# Altium schematic sheet IO.SchDoc(IO)
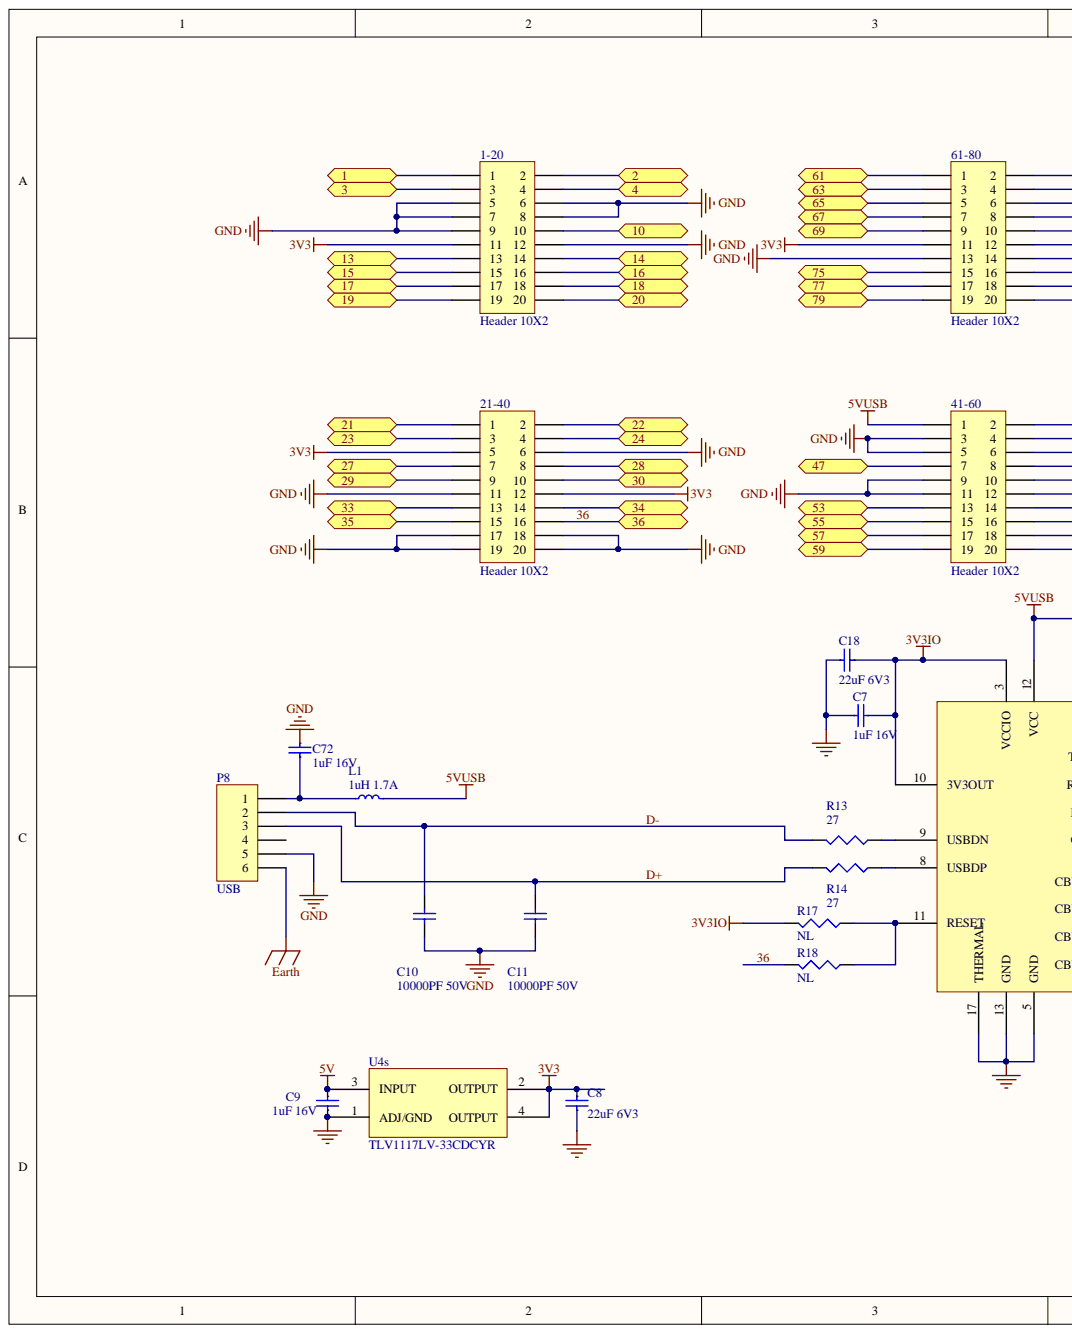
[diagram p0: IO.SchDoc, left]
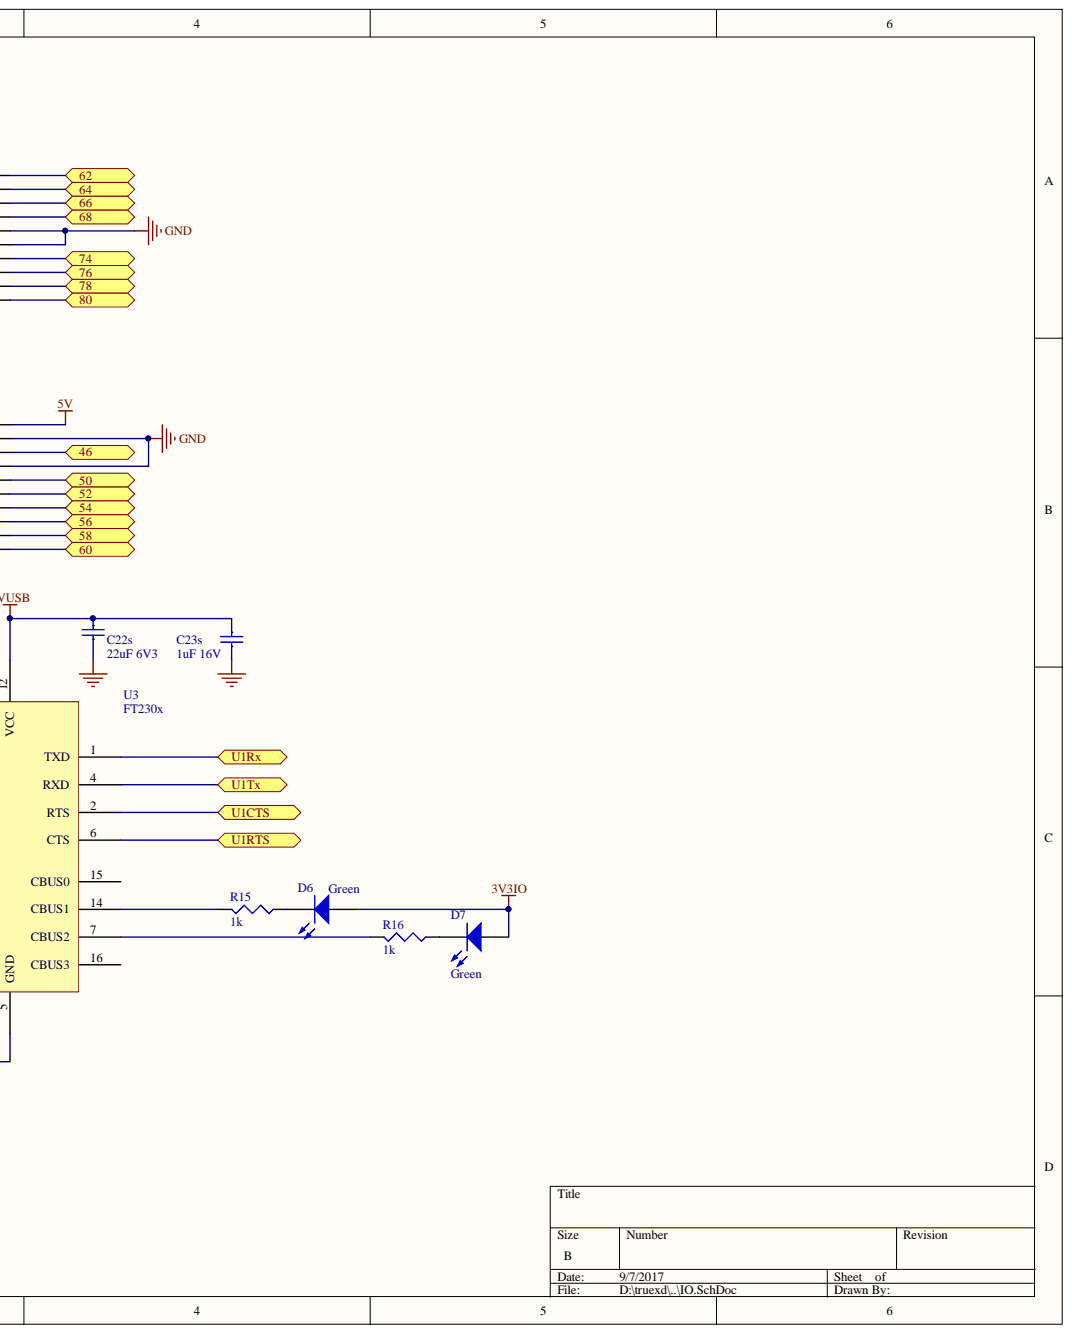
[diagram p1: IO.SchDoc, right]

## Parts
1-20  Header 10X2  [HDR2X10]
21-40  Header 10X2  [HDR2X10]
41-60  Header 10X2  [HDR2X10]
61-80  Header 10X2  [HDR2X10]
C7  1uF 16V  [CAP-0306 - BARE]
C8  22uF 6V3  [2012[0805]]
C9  1uF 16V  [CAP-0306 - BARE]
C10  10000PF 50V  [RES-0603 - Small]
C11  10000PF 50V  [RES-0603 - Small]
C18  22uF 6V3  [2012[0805]]
C22s  22uF 6V3  [2012[0805]]
C23s  1uF 16V  [CAP-0306 - BARE]
C72  1uF 16V  [CAP-0306 - BARE]
D6  Green  [LED_0603]
D7  Green  [LED_0603]
L1  1uH 1.7A  [IND-SMT-2]
P8  USB  [usb_mini_B_header]
R13  27  [RES-0603 - Small]
R14  27  [RES-0603 - Small]
R15  1k  [RES-0603 - Small]
R16  1k  [RES-0603 - Small]
R17  NL  [RES-0603 - Small]
R18  NL  [RES-0603 - Small]
U3  FT230x  [QFN16]
U4s  TLV1117LV-33CDCYR  [SOT-223]

## Ports
1, 2, 3, 4, 10, 13, 14, 15, 16, 17, 18, 19, 20, 21, 22, 23, 24, 27, 28, 29, 30, 33, 34, 35, 36, 46, 47, 50, 52, 53, 54, 55, 56, 57, 58, 59, 60, 61, 62, 63, 64, 65, 66, 67, 68, 69, 74, 75, 76, 77, 78, 79, 80, U1CTS, U1RTS, U1Rx, U1Tx

## Nets
3V3: 1-20.11 21-40.5 21-40.12 61-80.11 C8.2 U4s.2(OUTPUT) U4s.4(OUTPUT)
3V3IO: C7.1 C18.1 D6.1 D7.1 R17.2 U3.3(VCCIO) U3.10(3V3OUT)
5V: 41-60.2 C9.2 U4s.3(INPUT)
5VUSB: 41-60.1 C22s.2 C23s.2 L1.2 U3.12(VCC)
Earth: P8.6
GND: 1-20.5 1-20.6 1-20.7 1-20.8 1-20.9 1-20.12 21-40.6 21-40.11 21-40.17 21-40.18 21-40.19 21-40.20 41-60.3 41-60.4 41-60.5 41-60.8 41-60.9 41-60.11 61-80.10 61-80.12 61-80.13 C7.2 C8.1 C9.1 C10.1 C11.1 C18.2 C22s.1 C23s.1 C72.1 P8.5 U3.5(GND) U3.13(GND) U3.17(THERMAL) U4s.1(ADJ/GND)
1: 1-20.1 ; port 1
2: 1-20.2 ; port 2
3: 1-20.3 ; port 3
4: 1-20.4 ; port 4
10: 1-20.10 ; port 10
13: 1-20.13 ; port 13
14: 1-20.14 ; port 14
15: 1-20.15 ; port 15
16: 1-20.16 ; port 16
17: 1-20.17 ; port 17
18: 1-20.18 ; port 18
19: 1-20.19 ; port 19
20: 1-20.20 ; port 20
21: 21-40.1 ; port 21
22: 21-40.2 ; port 22
23: 21-40.3 ; port 23
24: 21-40.4 ; port 24
27: 21-40.7 ; port 27
28: 21-40.8 ; port 28
29: 21-40.9 ; port 29
30: 21-40.10 ; port 30
33: 21-40.13 ; port 33
34: 21-40.14 ; port 34
35: 21-40.15 ; port 35
36: 21-40.16 R18.2 ; port 36
46: 41-60.6 ; port 46
47: 41-60.7 ; port 47
50: 41-60.10 ; port 50
52: 41-60.12 ; port 52
53: 41-60.13 ; port 53
54: 41-60.14 ; port 54
55: 41-60.15 ; port 55
56: 41-60.16 ; port 56
57: 41-60.17 ; port 57
58: 41-60.18 ; port 58
59: 41-60.19 ; port 59
60: 41-60.20 ; port 60
61: 61-80.1 ; port 61
62: 61-80.2 ; port 62
63: 61-80.3 ; port 63
64: 61-80.4 ; port 64
65: 61-80.5 ; port 65
66: 61-80.6 ; port 66
67: 61-80.7 ; port 67
68: 61-80.8 ; port 68
69: 61-80.9 ; port 69
74: 61-80.14 ; port 74
75: 61-80.15 ; port 75
76: 61-80.16 ; port 76
77: 61-80.17 ; port 77
78: 61-80.18 ; port 78
79: 61-80.19 ; port 79
80: 61-80.20 ; port 80
D+: C11.2 P8.3 R14.2
D-: C10.2 P8.2 R13.2
U1CTS: U3.2(RTS) ; port U1CTS
U1RTS: U3.6(CTS) ; port U1RTS
U1Rx: U3.1(TXD) ; port U1Rx
U1Tx: U3.4(RXD) ; port U1Tx
NetC72_2: C72.2 L1.1 P8.1
NetD6_2: D6.2 R15.1
NetD7_2: D7.2 R16.1
NetR13_1: R13.1 U3.9(USBDN)
NetR14_1: R14.1 U3.8(USBDP)
NetR15_2: R15.2 U3.14(CBUS1)
NetR16_2: R16.2 U3.7(CBUS2)
NetR17_1: R17.1 R18.1 U3.11(RESET)

Not connected: P8 4; U3 15(CBUS0) 16(CBUS3)

## Library data of the parts
1-20 21-40 41-60 61-80 = Header 10X2: Header, 10-Pin, Dual row; Part Number: 1-534206-0; Manufacturer: TE Connectivity AMP Connectors; Signal Integrity: Connector; Mouser Part Number: SSW-110-01-F-D; Manufacturer Part #: 1-534206-0; Library Name: Miscellaneous Connectors.IntLib; LatestRevisionNote: Re-released for DXP Platform.; LatestRevisionDate: 17-Jul-2002; Digikey: A26460-ND; Cost: 3.10; Component Kind: Standard; Publisher: Altium Limited
C7 C9 C23s C72 = cap: CAP CER 1UF 16V X5R 0306; Part Number: C0816X5R1C105M050AC; Manufacturer: TDK Corporation; Location: 1; Do Not Load: USB; Manufacturer Part #: C0816X5R1C105M050AC; Digikey: 445-13750-1-ND; Cost: .52; Component Kind: Standard; Library Name: rleg.IntLib
C8 C18 C22s = cap: CAP CER 22UF 10V 20% X5R 0805; Part Number: C0805C226M9PACTU; Manufacturer: TDK; Location: 3; Do Not Load: USB; Manufacturer Part #: C0805C226M9PACTU; Digikey: 399-4696-1-ND; Cost: 0.45; Component Kind: Standard; Library Name: rleg.IntLib
C10 C11 = Cap Semi: CAP CER 10000PF 50V X7R 0603; Part Number: CC0603KRX7R9BB103; Manufacturer: AVX; Location: 1; Do Not Load: USB; Simulation: CAP; Signal Integrity: Cap Semi; Manufacturer Part #: CC0603KRX7R9BB103; Library Name: Miscellaneous Devices.IntLib; Digikey: 311-1085-1-ND; Cost: 0.05; Component Kind: Standard
D6 D7 = LED3: LED GREEN CLEAR 0603 SMD; Part Number: LTST-C191KGKT; Manufacturer: Lite-On Inc.; Location: 2; Do Not Load: USB; Simulation: LED3; Manufacturer Part #: LTST-C191KGKT; Library Name: Miscellaneous Devices.IntLib; Digikey: 160-1446-1-ND; Cost: 0.29; Component Kind: Standard
L1 = ind: FIXED IND 1UH 1.7A 55 MOHM SMD; Part Number: NLCV32T-1R0M-PFR; Manufacturer: TDK Corporation; Location: 2; Do Not Load: USB; Mouser Part Number: 810-NLCV32T-1R0M-PFR; Manufacturer Part #: NLCV32T-1R0M-PFR; Digikey: 445-3648-1-ND; Cost: 0.23; Component Kind: Standard; Library Name: rleg.IntLib
P8 = Header 6: Header, 6-Pin; Part Number: 1734035-2; Manufacturer: TE Connectivity AMP Connectors; Signal Integrity: Connector; Manufacturer Part #: 1734035-2; Library Name: Miscellaneous Connectors.IntLib; LatestRevisionNote: Re-released for DXP Platform.; LatestRevisionDate: 17-Jul-2002; Do Not Load: USB; Digikey: A31727CT-ND; Cost: 1.76; Component Kind: Standard; Publisher: Altium Limited
R13 R14 = Res3: RES SMD 27 OHM 1% 1/10W 0603; Part Number: RC0603FR-0727RL; Manufacturer: Yageo; Do Not Load: USB; Simulation: RESISTOR; Signal Integrity: Res; Manufacturer Part #: RC0603FR-0727RL; Library Name: Miscellaneous Devices.IntLib; Digikey: 311-27.0HRCT-ND; Cost: 0.10; Component Kind: Standard
R15 R16 = Res3: RES SMD 1K OHM 1% 1/10W 0603; Part Number: RC0603FR-071KL; Manufacturer: Yageo; Location: 1; Do Not Load: USB; Simulation: RESISTOR; Signal Integrity: Res; Manufacturer Part #: RC0603FR-071KL; Library Name: Miscellaneous Devices.IntLib; Digikey: 311-1.00KHRCT-ND; Cost: 0.03; Component Kind: Standard
R17 R18 = Res3: Location: 1; Do Not Load: USB; Simulation: RESISTOR; Signal Integrity: Res; Part Number: NL; Library Name: Miscellaneous Devices.IntLib; Digikey: NL; Cost: 0.03; Component Kind: Standard
U3 = FT230x: IC USB SERIAL BASIC UART 16QFN; Part Number: FT230XQ-R; Manufacturer Part #: FT230XQ-R; Do Not Load: USB; Digikey: 768-1130-1-ND; Cost: 2.30; Component Kind: Standard; Library Name: rleg.SchLib
U4s = TLV1117-33CDCYR: IC REG LINEAR 3.3V 1A SOT223-4; Part Number: TLV1117LV33DCYR; Manufacturer: Texas Instruments; Location: 4; Manufacturer Part #: TLV1117LV33DCYR; Digikey: 296-28778-1-ND; Cost: 0.70; Component Kind: Standard; Library Name: rleg.IntLib
